# Altium schematic sheet CW554_DB9_Breakout.SchDoc
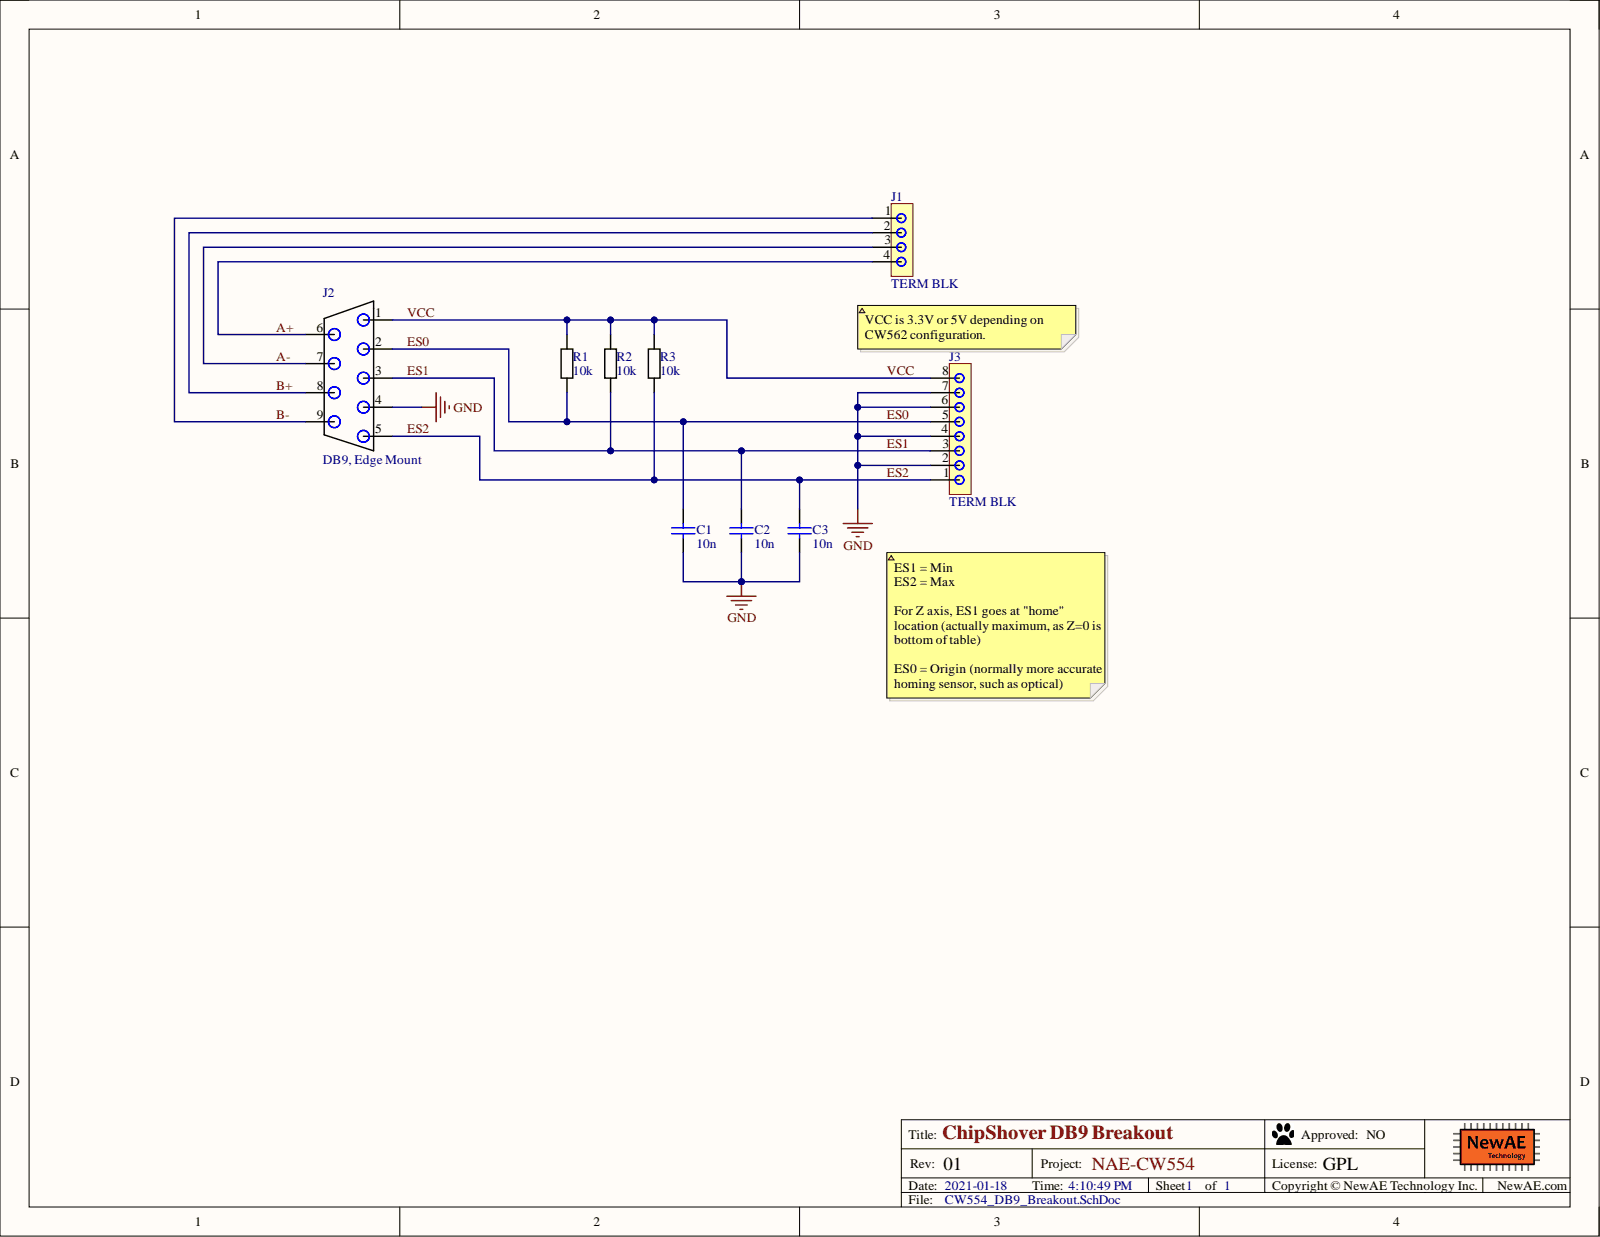
[diagram p0: CW554_DB9_Breakout.SchDoc]

## Parts
C1  10n  [0603]
C2  10n  [0603]
C3  10n  [0603]
J1  TERM BLK  [1984633]
J2  DB9, Edge Mount  [DB9_MALE_EDGE]
J3  TERM BLK  [1725711]
R1  10k  [0603]
R2  10k  [0603]
R3  10k  [0603]

## Nets
GND: C1.2 C2.2 C3.2 J2.4 J3.2 J3.4 J3.6 J3.7
A+: J1.4 J2.6
A-: J1.3 J2.7
B+: J1.2 J2.8
B-: J1.1 J2.9
ES0: C1.1 J2.2 J3.5 R1.2
ES1: C2.1 J2.3 J3.3 R2.2
ES2: C3.1 J2.5 J3.1 R3.2
VCC: J2.1 J3.8 R1.1 R2.1 R3.1

## Library data of the parts
C1-C3 = CCHIP-10n-0603: 10n, 0603; Case-Metric: 1608; Component Group: Capacitor; Database Table Name: main; Case-EIA: 0603; Component Kind: Standard; Component Type: Standard; Library Name: NewAE_AltiumLib.DBLib; Mounting Technology: SMT; Part Number: CCHIP-10n-0603; Pin Count: 2; Supplier 1: Digi-Key; Supplier Part Number 1: 399-1091-1-ND; Value: 10n
J1 = TERM_BLK_4P_3.5MM: PCB terminal block, 4P, 3.5mm; Manufacture 1: Phoneix Contact; Component Group: Connector; Database Table Name: main; PP Material Stack: 0; Component Kind: Standard; Component Type: Standard; Library Name: NewAE_AltiumLib.DBLib; Manufacture Part Number 1: 1984633; Part Number: TERM_BLK_4P_3.5MM; Pin Count: 4; PP Rotation: 0; PP Rotation1: 0; Supplier 1: Digi-Key; Supplier Part Number 1: 277-1860-ND
J2 = DB9_MALE_EDGE: Component Group: Connector; Database Table Name: main; PP Material Stack: 0; Component Kind: Standard; Component Type: Standard; Description: DB9, Edge Mount; Library Name: NewAE_AltiumLib.DBLib; Part Number: DB9_MALE_EDGE; Pin Count: 9; PP Rotation: 0; PP Rotation1: 0
J3 = TERM_BLK_8P_2.54MM: PCB terminal block, 8P, 2.54mm; Manufacture 1: Phoneix Contact; Component Group: Connector; Database Table Name: main; PP Material Stack: 0; Component Kind: Standard; Component Type: Standard; Library Name: NewAE_AltiumLib.DBLib; Manufacture Part Number 1: 1725711; Part Number: TERM_BLK_8P_2.54MM; Pin Count: 8; PP Rotation: 0; PP Rotation1: 0; Supplier 1: Digi-Key; Supplier 2: On-Shore Technology; Supplier Part Number 1: 277-1860-ND; Supplier Part Number 2: OSTVN08A150
R1-R3 = RCHIP-10K-0603: 10k res, 0603, 1%, 1/10W; Manufacture 1: Yageo; Manufacture Part Number 1: RC0603FR-0710KL; Case-Metric: 1608; Component Group: Resistor; Database Table Name: main; Rated Power: 0.1W; Rated Voltage: 75VDC; Case-EIA: 0603; Component Kind: Standard; Component Type: Standard; Library Name: NewAE_AltiumLib.DBLib; Mounting Technology: SMT; Part Number: RCHIP-10K-0603; Pin Count: 2; Supplier 1: Digi-Key; Supplier Part Number 1: 311-10.0KHRCT-ND; Temperature Range: -55C to 155C; Tolerance: 1%; Value: 10k
